# Altium schematic sheet p01_mcu.SchDoc
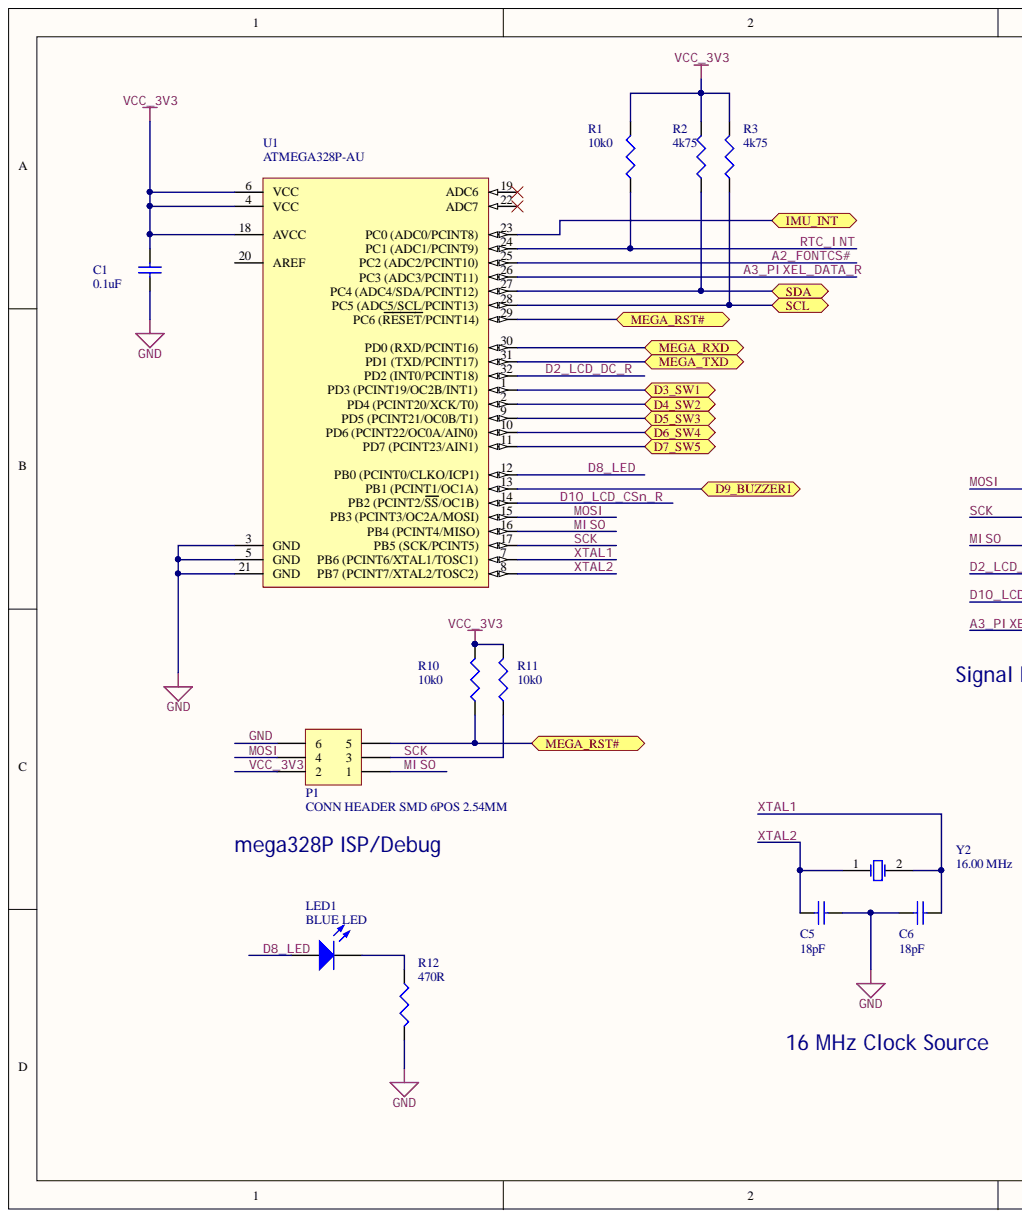
[diagram p0: p01_mcu.SchDoc, left]
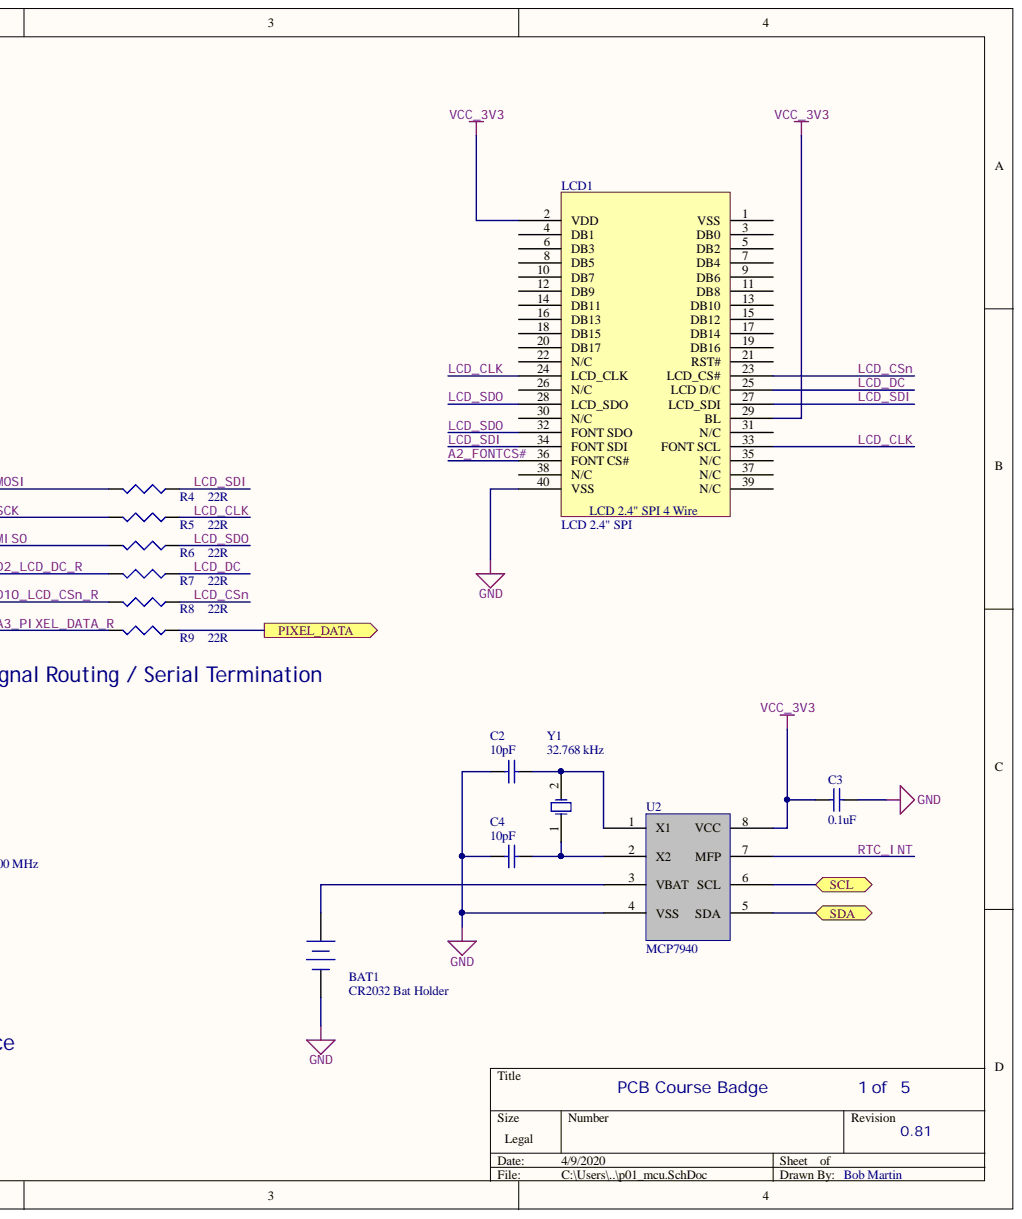
[diagram p1: p01_mcu.SchDoc, right]

## Parts
BAT1  Battery  [BAT-2]  (value not drawn)
C1  value 0.1uF  [RAD-0.3]  (hidden comment: Cap)
C2  value 10pF  [RAD-0.3]  (hidden comment: Cap)
C3  value 0.1uF  [RAD-0.3]  (hidden comment: Cap)
C4  value 10pF  [RAD-0.3]  (hidden comment: Cap)
C5  value 18pF  [RAD-0.3]  (hidden comment: Cap)
C6  value 18pF  [RAD-0.3]  (hidden comment: Cap)
LCD1  LCD 2.4" SPI
LED1  BLUE LED  [LED-0]
P1  value CONN HEADER SMD 6POS 2.54MM  [HDR2X3]  (hidden comment: Header 3X2)
R1  value 10k0  [J1-0603]  (hidden comment: Res3)
R2  value 4k75  [J1-0603]  (hidden comment: Res3)
R3  value 4k75  [J1-0603]  (hidden comment: Res3)
R4  value 22R  [J1-0603]  (hidden comment: Res3)
R5  value 22R  [J1-0603]  (hidden comment: Res3)
R6  value 22R  [J1-0603]  (hidden comment: Res3)
R7  value 22R  [J1-0603]  (hidden comment: Res3)
R8  value 22R  [J1-0603]  (hidden comment: Res3)
R9  value 22R  [J1-0603]  (hidden comment: Res3)
R10  value 10k0  [J1-0603]  (hidden comment: Res3)
R11  value 10k0  [J1-0603]  (hidden comment: Res3)
R12  value 470R  [J1-0603]  (hidden comment: Res3)
U1  ATmega328P-AU  [32A_N]
U2  MCP7940
Y1  value 32.768 kHz  [R38]  (hidden comment: XTAL)
Y2  value 16.00 MHz  [R38]  (hidden comment: XTAL)

## Ports
D3_SW1, D4_SW2, D5_SW3, D6_SW4, D7_SW5, D9_BUZZER1, IMU_INT, MEGA_RST#, MEGA_RXD, MEGA_TXD, PIXEL_DATA, SCL, SDA

## Nets
A2_FONTCS#: LCD1.36(FONT_CS#) U1.25
A3_PIXEL_DATA_R: R9.2 U1.26(PC3_(ADC3/PCINT11))
D2_LCD_DC_R: R7.2 U1.32(PD2_(INT0/PCINT18))
D3_SW1: U1.1(PD3_(PCINT19/OC2B/INT1)) ; port D3_SW1
D4_SW2: U1.2(PD4_(PCINT20/XCK/T0)) ; port D4_SW2
D5_SW3: U1.9(PD5_(PCINT21/OC0B/T1)) ; port D5_SW3
D6_SW4: U1.10(PD6_(PCINT22/OC0A/AIN0)) ; port D6_SW4
D7_SW5: U1.11(PD7_(PCINT23/AIN1)) ; port D7_SW5
D8_LED: LED1.1 U1.12(PB0_(PCINT0/CLKO/ICP1))
D9_BUZZER1: U1.13(PB1_(PCINT1/OC1A)) ; port D9_BUZZER1
D10_LCD_CSN_R: R8.2 U1.14(PB2_(PCINT2/SS/OC1B))
GND: BAT1.2 C1.1 C2.1 C3.1 C4.1 C5.2 C6.1 LCD1.40(VSS) P1.6 R12.2 U1.3(GND) U1.5(GND) U1.21(GND) U2.4(VSS)
IMU_INT: U1.23(PC0_(ADC0/PCINT8)) ; port IMU_INT
LCD_CLK: LCD1.24(LCD_CLK) LCD1.33(FONT_SCL) R5.1
LCD_CSN: LCD1.23(LCD_CS#) R8.1
LCD_DC: LCD1.25(D/C) R7.1
LCD_SDI: LCD1.27(LCD_SDI) LCD1.34(FONT_SDI) R4.1
LCD_SDO: LCD1.28(LCD_SDO) LCD1.32(FONT_SDO) R6.1
MEGA_RST#: P1.5 R10.1 U1.29(PC6_(RESET/PCINT14)) ; port MEGA_RST#
MEGA_RXD: U1.30(PD0_(RXD/PCINT16)) ; port MEGA_RXD
MEGA_TXD: U1.31(PD1_(TXD/PCINT17)) ; port MEGA_TXD
MISO: P1.1 R6.2 U1.16(PB4_(PCINT4/MISO))
MOSI: P1.4 R4.2 U1.15(PB3_(PCINT3/OC2A/MOSI))
PIXEL_DATA: R9.1 ; port PIXEL_DATA
RTC_INT: R1.1 U1.24(PC1_(ADC1/PCINT9)) U2.7(MFP)
SCK: P1.3 R5.2 R11.1 U1.17(PB5_(SCK/PCINT5))
SCL: R3.1 U1.28(PC5_(ADC5/SCL/PCINT13)) U2.6(SCL) ; port SCL
SDA: R2.1 U1.27(PC4_(ADC4/SDA/PCINT12)) U2.5(SDA) ; port SDA
VCC_3V3: C1.2 C3.2 LCD1.2(VDD) LCD1.29(BL) P1.2 R1.2 R2.2 R3.2 R10.2 R11.2 U1.4(VCC) U1.6(VCC) U1.18(AVCC) U2.8(VCC)
XTAL1: C6.2 U1.7 Y2.2
XTAL2: C5.1 U1.8 Y2.1
NetBAT1_1: BAT1.1 U2.3(VBAT)
NetC2_2: C2.2 U2.1(X1) Y1.2
NetC4_2: C4.2 U2.2(X2) Y1.1
NetLED1_2: LED1.2 R12.1

Not connected: LCD1 1(VSS) 3(DB0) 4(DB1) 5(DB2) 6(DB3) 7(DB4) 8(DB5) 9(DB6) 10(DB7) 11(DB8) 12(DB9) 13(DB10) 14(DB11) 15(DB12) 16(DB13) 17(DB14) 18(DB15) 19(DB16) 20(DB17) 21(RST#) 22(N/C) 26(N/C) 30(N/C) 31(N/C) 35(N/C) 37(N/C) 38(N/C) 39(N/C); U1 19(ADC6) 20(AREF) 22(ADC7)

## Library data of the parts
BAT1 = Battery: Multicell Battery; Manufacturer: MPD (Memory Protection Devices); MPN: BK-883; Detail: CR2032 Bat Holder; Component Kind: Standard; Digi-Key PN: BK-883-ND; Library Name: Miscellaneous Devices.IntLib
C1 C3 = Cap: Capacitor; Manufacturer: KEMET; MPN: C0603C104Z3VACTU; Detail: CAP CER 0.1UF 25V Y5V 0603; Component Kind: Standard; Digi-Key PN: 399-1100-1-ND; Library Name: Miscellaneous Devices.IntLib; Signal Integrity: Cap; Simulation: CAP
C2 C4 = Cap: Capacitor; Manufacturer: KEMET; MPN: C0402C100J3GACTU; Detail: CAP CER 10PF 25V C0G/NP0 0402; Component Kind: Standard; Digi-Key PN: 399-7746-1-ND; Library Name: Miscellaneous Devices.IntLib; Signal Integrity: Cap; Simulation: CAP
C5 C6 = Cap: Capacitor; Manufacturer: KEMET; MPN: C0603C180J1GACTU; Detail: CAP CER 18PF 100V C0G/NP0 0603; Component Kind: Standard; Digi-Key PN: 399-7865-1-ND; Library Name: Miscellaneous Devices.IntLib; Signal Integrity: Cap; Simulation: CAP
LCD1 = LCD-2.4: Manufacturer: Buydisplay; MPN: ER-TFTM024-3; Detail: TFT LCD; Value: TFT LCD; Component Kind: Standard; Digi-Key PN: n/a; Library Name: badge1_v06.SCHLIB
LED1 = LED0: Typical INFRARED GaAs LED; Manufacturer: OSRAM Opto Semiconductors Inc.; MPN: LB Q39G-L2OO-35-1; Detail: LED BLUE DIFFUSED 0603 SMD; Value: LED BLUE DIFFUSED 0603 SMD; Component Kind: Standard; Digi-Key PN: 475-2816-1-ND; Library Name: Miscellaneous Devices.IntLib; Simulation: LED0
P1 = Header 3X2: Header, 3-Pin, Dual row; Manufacturer: Molex; MPN: 0015910060; Component Kind: Standard; Detail: CONN HEADER SMD 6POS 2.54MM; Digi-Key PN: WM17457-ND; Library Name: Miscellaneous Connectors.IntLib; Signal Integrity: Connector
R1 R10 R11 = Res3: Resistor; Manufacturer: Stackpole Electronics Inc; MPN: RNCP0603FTD10K0; Detail: RES 10K OHM 1% 1/8W 0603; Component Kind: Standard; Digi-Key PN: RNCP0603FTD10K0CT-ND; Library Name: Miscellaneous Devices.IntLib; Signal Integrity: Res; Simulation: RESISTOR
R2 R3 = Res3: Resistor; Manufacturer: Stackpole Electronics Inc; MPN: RNCP0603FTD4K75; Detail: RES 4.75K OHM 1% 1/8W 0603; Component Kind: Standard; Digi-Key PN: RNCP0603FTD4K75TR-ND; Library Name: Miscellaneous Devices.IntLib; Signal Integrity: Res; Simulation: RESISTOR
R4-R9 = Res3: Resistor; Manufacturer: Stackpole Electronics Inc; MPN: RMCF0603JT22R0; Detail: RES 22 OHM 5% 1/10W 0603; Component Kind: Standard; Digi-Key PN: RMCF0603JT22R0CT-ND; Library Name: Miscellaneous Devices.IntLib; Signal Integrity: Res; Simulation: RESISTOR
R12 = Res3: Resistor; Manufacturer: Stackpole Electronics Inc; MPN: RMCF0603JT470R; Detail: RES 470 OHM 5% 1/10W 0603; Component Kind: Standard; Digi-Key PN: RMCF0603JT470RCT-ND; Library Name: Miscellaneous Devices.IntLib; Signal Integrity: Res; Simulation: RESISTOR
U1 = ATmega328P-AU: 8-bit AVR Microcontroller, 32KB Flash, 1KB EEPROM, 2KB SRAM, 32-pin TQFP, Industrial Grade (-40°C to 85°C); Manufacturer: Microchip Technology; MPN: ATMEGA328P-AUR; Detail: AVR AVR® ATmega Microcontroller IC 8-Bit 20MHz 32KB (16K x 16) FLASH 32-TQFP (7x7); Component Kind: Standard; Digi-Key PN: ATMEGA328P-AURCT-ND; Library Name: Atmel Microcontroller megaAVR.IntLib; Value: ATMEGA328P-AU
U2 = MCP7940N: I2C RTC w Battery Backup; Manufacturer: Microchip Technology; MPN: MCP7940N-I/SN; Detail: IC RTC CLK/CALENDAR I2C 8-SOIC; Value: MCP7940 RTC; Component Kind: Standard; Digi-Key PN: MCP7940N-I/SN-ND; Library Name: badge1.SCHLIB
Y1 = XTAL: Crystal Oscillator; Manufacturer: EPSON; MPN: MC-405 32.7680K-E3: ROHS; Detail: CRYSTAL 32.7680 KHZ 6.0PF SMD; Component Kind: Standard; Digi-Key PN: SER4311TR-ND; Library Name: Miscellaneous Devices.IntLib; Simulation: XTAL
Y2 = XTAL: Crystal Oscillator; Manufacturer: Abracon LLC; MPN: ABM3-16.000MHZ-B2-T; Detail: CRYSTAL 16.0000MHZ 18PF SMD; Component Kind: Standard; Digi-Key PN: 535-9103-1-ND; Library Name: Miscellaneous Devices.IntLib; Simulation: XTAL
